# Altium schematic sheet Schematic.SchDoc(Schematic)
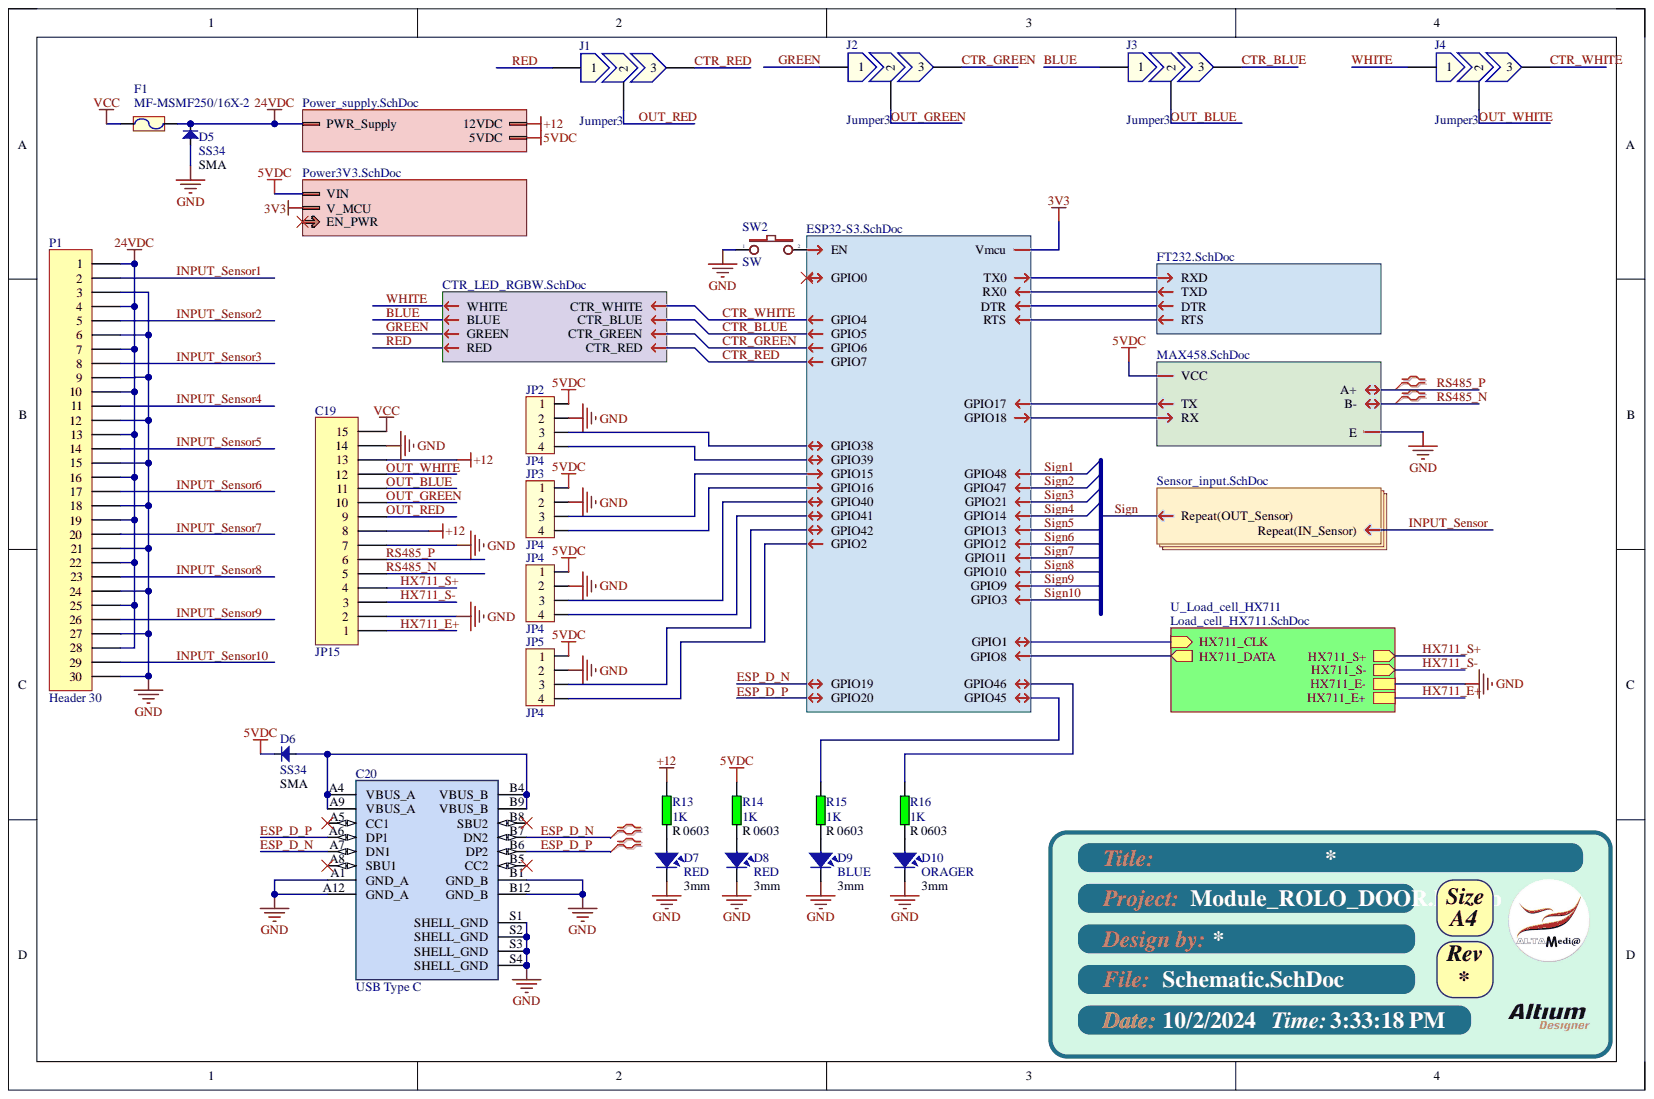
[diagram p0: Schematic.SchDoc]

## Parts
C19  JP15  [KF141-2.54-15]
C20  USB Type C  [USB Type C 16P]
D5  SS34  Size: SMA  [DIO-SMD-106]
D6  SS34  Size: SMA  [DIO-SMD-106]
D7  RED  Size: 3mm  [LED_RED_3MM]
D8  RED  Size: 3mm  [LED_RED_3MM]
D9  BLUE  Size: 3mm  [LED_RED_3MM]
D10  ORAGER  Size: 3mm  [LED_RED_3MM]
F1  MF-MSMF250/16X-2  [FUSER_MF-MSMF250/16X]
J1  Jumper3  [SB_JUM3]
J2  Jumper3  [SB_JUM3]
J3  Jumper3  [SB_JUM3]
J4  Jumper3  [SB_JUM3]
JP2  JP4  [CON4]
JP3  JP4  [CON4]
JP4  JP4  [CON4]
JP5  JP4  [CON4]
P1  Header 30  [KF141-2.54-30]
R13  1K  [R-0603]
R14  1K  [R-0603]
R15  1K  [R-0603]
R16  1K  [R-0603]
SW2  SW  [TS36CA-0.6]

## Nets
5VDC: D6.2 JP2.1 JP3.1 JP4.1 JP5.1 R14.1
24VDC: D5.2 F1.2 P1.1 P1.4 P1.7 P1.10 P1.13 P1.16 P1.19 P1.22 P1.25 P1.28
+12: C19.8 C19.13 R13.1
GND: C19.2 C19.7 C19.14 C20.A1(GND_A) C20.A12(GND_A) C20.B1(GND_B) C20.B12(GND_B) C20.S1(SHELL_GND) C20.S2(SHELL_GND) C20.S3(SHELL_GND) C20.S4(SHELL_GND) D5.1 D7.2 D8.2 D9.2 D10.2 JP2.2 JP3.2 JP4.2 JP5.2 P1.3 P1.6 P1.9 P1.12 P1.15 P1.18 P1.21 P1.24 P1.27 P1.30 SW2.1
VCC: C19.15 F1.1
BLUE: J3.1
CTR_BLUE: J3.3
CTR_GREEN: J2.3
CTR_RED: J1.3
CTR_WHITE: J4.3
ESP_D_N: C20.A7(DN1) C20.B7(DN2)
ESP_D_P: C20.A6(DP1) C20.B6(DP2)
GREEN: J2.1
HX711_E+: C19.1
HX711_S+: C19.4
HX711_S-: C19.3
INPUT_Sensor1: P1.2
INPUT_Sensor2: P1.5
INPUT_Sensor3: P1.8
INPUT_Sensor4: P1.11
INPUT_Sensor5: P1.14
INPUT_Sensor6: P1.17
INPUT_Sensor7: P1.20
INPUT_Sensor8: P1.23
INPUT_Sensor9: P1.26
INPUT_Sensor10: P1.29
OUT_BLUE: C19.11 J3.2
OUT_GREEN: C19.10 J2.2
OUT_RED: C19.9 J1.2
OUT_WHITE: C19.12 J4.2
RED: J1.1
RS485_N: C19.5
RS485_P: C19.6
WHITE: J4.1
NetC20_A4: C20.A4(VBUS_A) C20.A9(VBUS_A) C20.B4(VBUS_B) C20.B9(VBUS_B) D6.1
NetC20_A5: C20.A5(CC1)
NetC20_A8: C20.A8(SBU1)
NetC20_B5: C20.B5(CC2)
NetC20_B8: C20.B8(SBU2)
NetD7_1: D7.1 R13.2
NetD8_1: D8.1 R14.2
NetD9_1: D9.1 R15.2
NetD10_1: D10.1 R16.2
NetJP2_3: JP2.3
NetJP2_4: JP2.4
NetJP3_3: JP3.3
NetJP3_4: JP3.4
NetJP4_3: JP4.3
NetJP4_4: JP4.4
NetJP5_3: JP5.3
NetJP5_4: JP5.4
NetR15_1: R15.1
NetR16_1: R16.1
NetSW2_2: SW2.2
3V3:
DTR:
EN_PWR:
GPIO0:
GPIO1:
GPIO8:
GPIO17:
GPIO18:
RTS:
RX0:
RXD:
Sign1:
Sign2:
Sign3:
Sign4:
Sign5:
Sign6:
Sign7:
Sign8:
Sign9:
Sign10:

## Library data of the parts
C19 = JP15: WR-PHD 2.54 mm Angled Socket Header; Manufacturer: W¨¹rth Elektronik eiSos GmbH; partNo: 613015143121; ComponentLink1 Description: Manufacturer; ComponentLink2 Description: Datasheet; NoPins: 15; Component Kind: Standard; ComponentLink1URL: http://www.we-online.com; ComponentLink2URL: http://katalog.we-online.de/em/datasheet/6130xx143121.pdf; Created Date: 29 March 2013; Library Name: My Library.SchLib; Created by: Karrer Zheng
C20 = USB TypeC 16P: CSP-USC16-TR Valcon USB Type C Surface Mount PCB Socket; Availability: No availability data; Check_prices: https://www.snapeda.com/parts/CSP-USC16-TR/Valcon/view-part/4783629/?ref=eda; Component Kind: Standard; Library Name: My Library.SchLib; MF: Valcon; MP: CSP-USC16-TR; Package: None; Price: None; Purchase-URL: https://pricing.snapeda.com/search/part/CSP-USC16-TR/?ref=eda; SnapEDA_Link: https://www.snapeda.com/parts/CSP-USC16-TR/Valcon/view-part/4783629/?ref=snap; Value: USB Type C
D5 D6 = Diode SMD: Default Diode; Component Kind: Standard; Library Name: My Library.SchLib; Published: 8-Jun-2000; Revision: July-2002: Re-released for DXP Platform.; Value: SS34; Publisher: Altium Limited
D7 D8 = LED: LED Single colour Green and Red; FNC: Power; Component Kind: Standard; Library Name: My Library.SchLib; Value: RED
D9 = LED: LED Single colour Green and Red; FNC: RUN; Component Kind: Standard; Library Name: My Library.SchLib; Value: BLUE
D10 = LED: LED Single colour Green and Red; FNC: Waring; Component Kind: Standard; Library Name: My Library.SchLib; Value: ORAGER
F1 = Fuse: Designed by: VanDungEvn; Component Kind: Standard; Description: Fuse; Library Name: My Library.SchLib; Value: MF-MSMF250/16X-2
J1-J4 = Jumper3: Jumper, 3-Pin; Component Kind: Standard; Library Name: My Library.SchLib
JP2-JP5 = Con4: Connector 4; Component Kind: Standard; Library Name: My Library.SchLib; Value: Connector 4
P1 = Header 30: Header, 30-Pin; Component Kind: Standard; LatestRevisionDate: 17-Jul-2002; LatestRevisionNote: Re-released for DXP Platform.; Library Name: My Library.SchLib; Signal Integrity: Connector; Publisher: Altium Limited
R13-R16 = RES: SAISO: 5%; Size2: R 0805; Component Kind: Standard; Library Name: Schlib.SchLib; Size: R 0603; Value: 1K
SW2 = SW2: Switch button 2 pin; Value: SW chip 2 pin; Component Kind: Standard; Library Name: My Library.SchLib
